# Altium schematic sheet Main uC.SchDoc("Main uC")
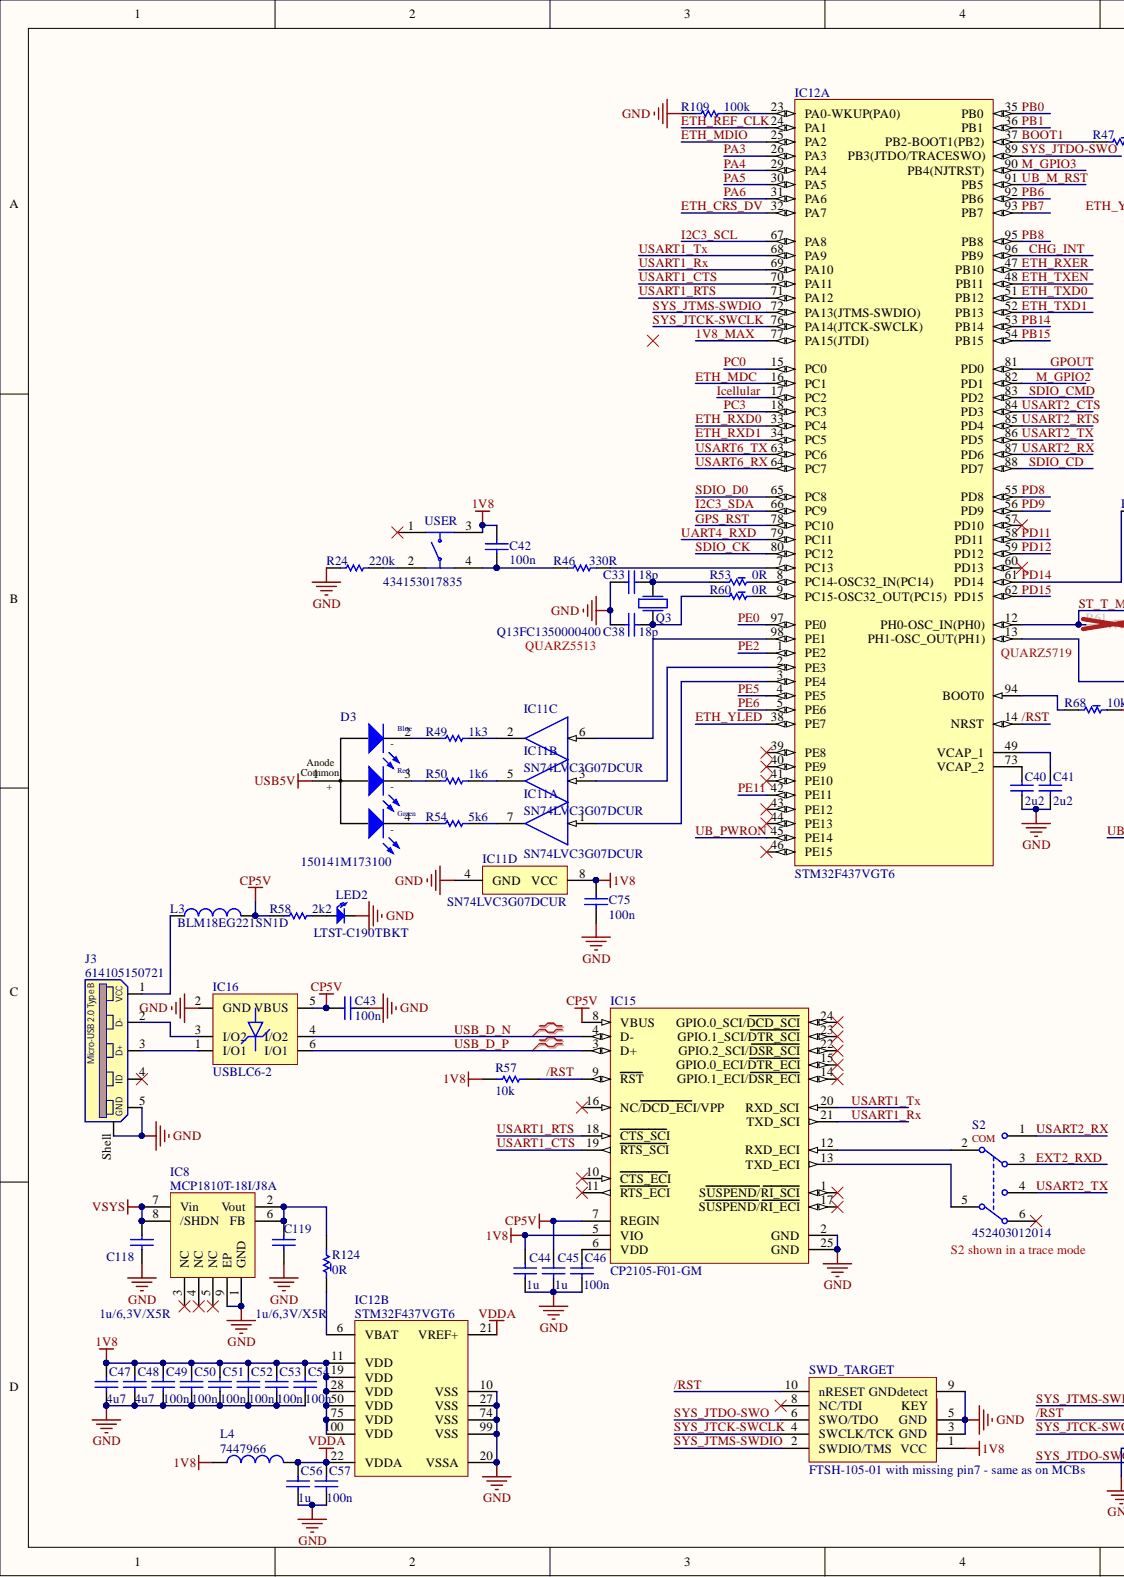
[diagram p0: Main uC.SchDoc, left]
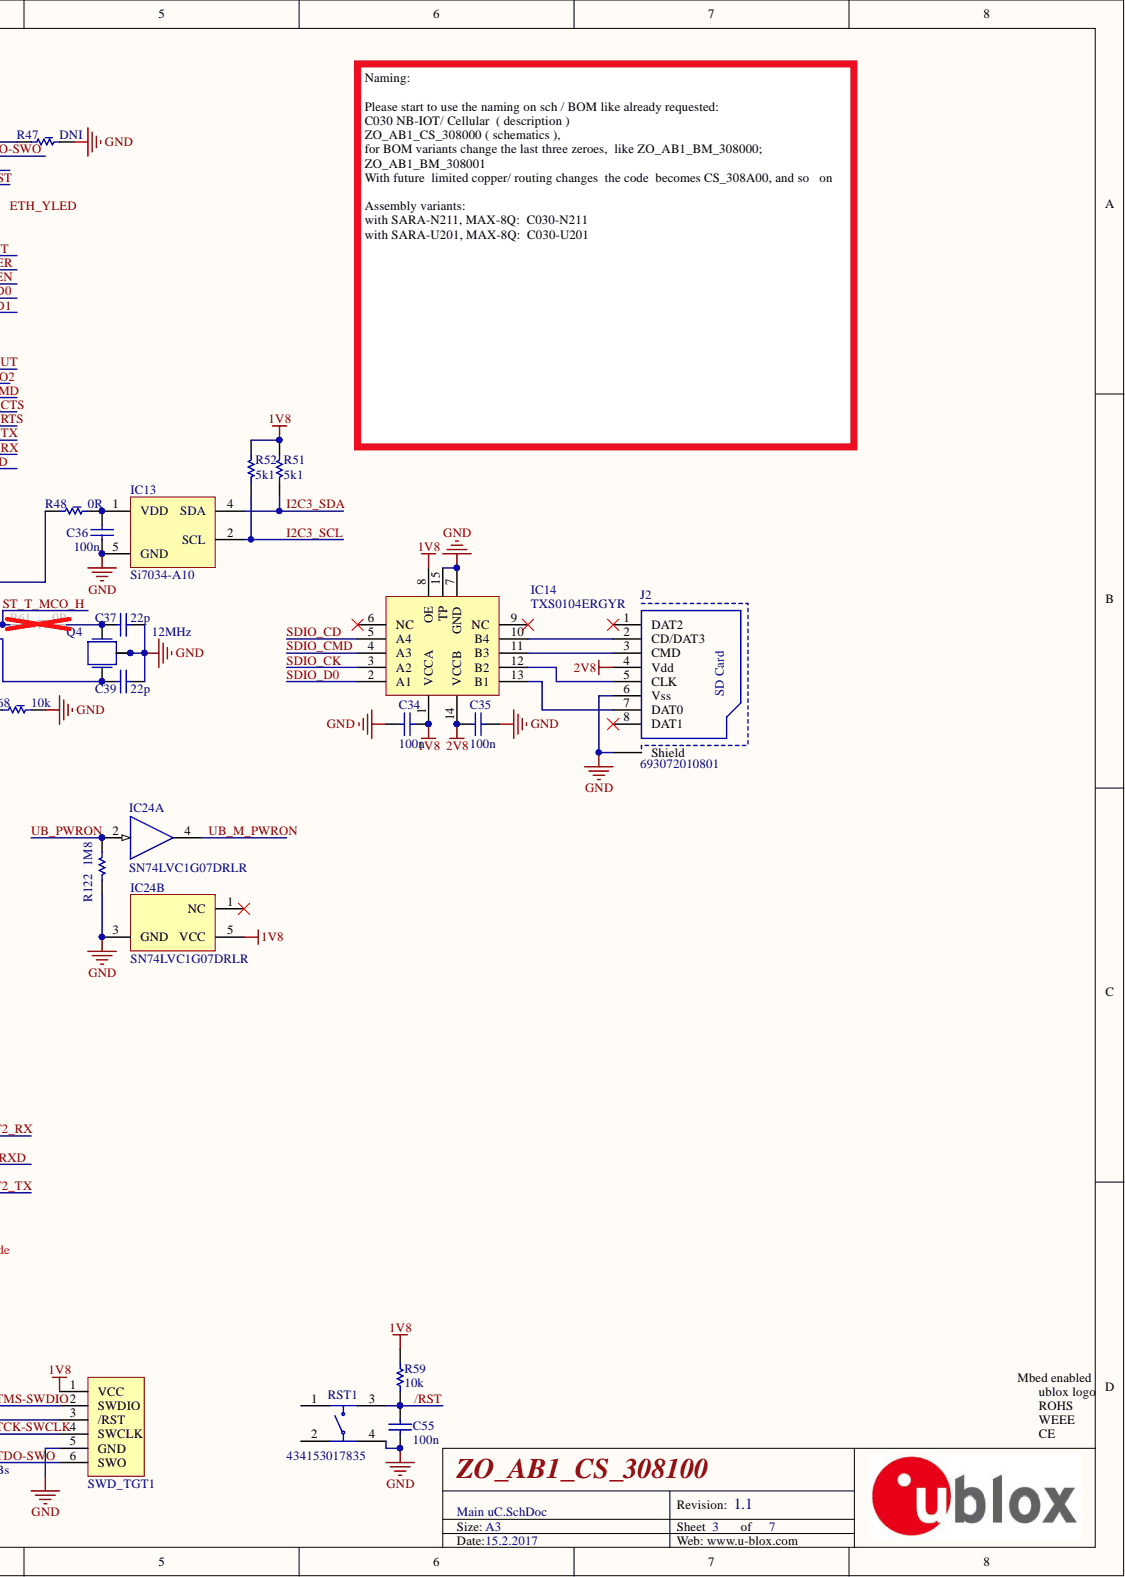
[diagram p1: Main uC.SchDoc, right]

## Parts
C33  18p  [C0402]
C34  100n  [C0402]
C35  100n  [C0402]
C36  100n  [C0402]
C37  22p  [C0402]
C38  18p  [C0402]
C39  22p  [C0402]
C40  2u2  [C0603]
C41  2u2  [C0603]
C42  100n  [C0402]
C43  100n  [C0402]
C44  1u  [C0603]
C45  1u  [C0603]
C46  100n  [C0402]
C47  4u7  [C0603]
C48  4u7  [C0603]
C49  100n  [C0402]
C50  100n  [C0402]
C51  100n  [C0402]
C52  100n  [C0402]
C53  100n  [C0402]
C54  100n  [C0402]
C55  100n  [C0402]
C56  1u  [C0603]
C57  100n  [C0402]
C75  100n  [C0402]
C118  1u/6,3V/X5R  [C0402]
C119  1u/6,3V/X5R  [C0402]
CEsign  CEsign  [CE - overlay]  (value not drawn)  (no BOM)
D3  150141M173100  [3528]
IC8  MCP1810T-18I/J8A  [DFN8(ST)]
IC11  SN74LVC3G07DCUR  [DCU0008A_L]  (parts A,B,C,D)
IC12  STM32F437VGT6  [STM-LQFP100_L]  (parts A,B)
IC13  Si7034-A10  [Si7034]
IC14  TXS0104ERGYR  [RGY-14N]
IC15  CP2105-F01-GM  [SLAB-QFN-24-2675x2675TP_N]
IC16  USBLC6-2  [SOT23-6L]
IC24  SN74LVC1G07DRLR  [DRL0005A_L]  (parts A,B)
J2  693072010801  [693072010801]
J3  614105150721  [614105150721]
L3  BLM18EG221SN1D  [L0603]
L4  7447966  [L0603]
LED2  LTST-C190TBKT  [LED0603 - BLUE]
Mbed_enabled  Mbed enabled  [Mbed enabled]  (no BOM)
Q3  Q13FC1350000400  [Q3215]
Q4  12MHz  [Q3225]
R24  220k  [R0402]
R46  330R  [R0402]
R47  DNI  [R0603pacman]
R48  0R  [R0603pacman]
R49  1k3  [R0402]
R50  1k6  [R0402]
R51  5k1  [R0402]
R52  5k1  [R0402]
R53  0R  [R0603pacman]
R54  5k6  [R0402]
R57  10k  [R0402]
R58  2k2  [R0402]
R59  10k  [R0402]
R60  0R  [R0603pacman]
R61  0R  [R0603pacman]
R68  10k  [R0603pacman]
R109  100k  [R0402]
R122  1M8  [R0402]
R124  0R  [R0603pacman]
ROHSsign  ROHSsign  [ROHSgrdi_overlay]  (value not drawn)  (no BOM)
RST1  434153017835  [434153017835]
S2  452403012014  [452403012014]
SWD_TARGET  FTSH-105-01 with missing pin7 - same as on MCBs  [SWD10 pin-7]
SWD_TGT1  TC2030-CTL-NL  [TC2030-MCP-NL]  (value not drawn)  (no BOM)
ublox_logo  ublox logo  [Ublox logo mini]  (no BOM)
USER  434153017835  [434153017835]
WEEEsign  WEEEsign  [WEEE - overlay]  (value not drawn)  (no BOM)

## Nets
1V8: C34.2 C42.2 C44.2 C47.2 C48.2 C49.2 C50.2 C51.2 C52.2 C53.2 C54.2 C75.2 IC11.8(VCC) IC12.11(VDD) IC12.19(VDD) IC12.28(VDD) IC12.50(VDD) IC12.75(VDD) IC12.100(VDD) IC14.1(VCCA) IC14.8(OE) IC15.5(VIO) IC24.5(VCC) L4.1 R51.2 R52.2 R57.1 R59.1 SWD_TARGET.1(VCC) SWD_TGT1.1(VCC) USER.3
2V8: C35.2 IC14.14(VCCB) J2.4(Vdd)
CP5V: C43.2 C45.2 IC15.7(REGIN) IC15.8(VBUS) IC16.5(VBUS) L3.2 R58.1
GND: C33.2 C34.1 C35.1 C36.1 C37.1 C38.2 C39.1 C40.1 C41.1 C43.1 C44.1 C45.1 C46.1 C47.1 C48.1 C49.1 C50.1 C51.1 C52.1 C53.1 C54.1 C55.1 C56.1 C57.1 C75.1 C118.1 C119.1 IC8.1(GND) IC8.9(EP) IC11.4(GND) IC12.10(VSS) IC12.20(VSSA) IC12.27(VSS) IC12.74(VSS) IC12.99(VSS) IC13.5(GND) IC14.7(GND) IC14.15(TP) IC15.2(GND) IC15.25(GND) IC16.2(GND) IC24.3(GND) J2.6(Vss) J2.Shield J3.5 J3.Shell LED2.K Q4.2 Q4.4 R24.2 R47.2 R68.2 R109.2 R122.1 RST1.4 SWD_TARGET.3(GND) SWD_TARGET.5(GND) SWD_TARGET.9(GNDdetect) SWD_TGT1.5(GND)
USB5V: D3.1
VDDA: C56.2 C57.2 IC12.21(VREF+) IC12.22(VDDA) L4.2
VSYS: C118.2 IC8.7(Vin) IC8.8(/SHDN)
1V8_MAX: IC12.77(PA15(JTDI))
/RST: C55.2 IC12.14(NRST) IC15.9(RST) R57.2 R59.2 RST1.3 SWD_TARGET.10(nRESET) SWD_TGT1.3(/RST)
BOOT1: IC12.37(PB2-BOOT1(PB2)) R47.1
CHG_INT: IC12.96(PB9)
ETH_CRS_DV: IC12.32(PA7)
ETH_MDC: IC12.16(PC1)
ETH_MDIO: IC12.25(PA2)
ETH_REF_CLK: IC12.24(PA1)
ETH_RXD0: IC12.33(PC4)
ETH_RXD1: IC12.34(PC5)
ETH_RXER: IC12.47(PB10)
ETH_TXD0: IC12.51(PB12)
ETH_TXD1: IC12.52(PB13)
ETH_TXEN: IC12.48(PB11)
ETH_YLED: IC12.38(PE7)
EXT2_RXD: S2.3
GPOUT: IC12.81(PD0)
GPS_RST: IC12.78(PC10)
I2C3_SCL: IC12.67(PA8) IC13.2(SCL) R52.1
I2C3_SDA: IC12.66(PC9) IC13.4(SDA) R51.1
ICELLULAR: IC12.17(PC2)
M_GPIO2: IC12.82(PD1)
M_GPIO3: IC12.90(PB4(NJTRST))
PA3: IC12.26(PA3)
PA4: IC12.29(PA4)
PA5: IC12.30(PA5)
PA6: IC12.31(PA6)
PB0: IC12.35(PB0)
PB1: IC12.36(PB1)
PB6: IC12.92(PB6)
PB7: IC12.93(PB7)
PB8: IC12.95(PB8)
PB14: IC12.53(PB14)
PB15: IC12.54(PB15)
PC0: IC12.15(PC0)
PC3: IC12.18(PC3)
PD8: IC12.55(PD8)
PD9: IC12.56(PD9)
PD11: IC12.58(PD11)
PD12: IC12.59(PD12)
PD14: IC12.61(PD14) R48.1
PD15: IC12.62(PD15)
PE0: IC12.97(PE0)
PE2: IC12.1(PE2)
PE5: IC12.4(PE5)
PE6: IC12.5(PE6)
PE11: IC12.42(PE11)
SDIO_CD: IC12.88(PD7) IC14.5(A4)
SDIO_CK: IC12.80(PC12) IC14.3(A2)
SDIO_CMD: IC12.83(PD2) IC14.4(A3)
SDIO_D0: IC12.65(PC8) IC14.2(A1)
ST_T_MCO_H: IC12.12(PH0-OSC_IN(PH0)) R61.1
SYS_JTCK-SWCLK: IC12.76(PA14(JTCK-SWCLK)) SWD_TARGET.4(SWCLK/TCK) SWD_TGT1.4(SWCLK)
SYS_JTDO-SWO: IC12.89(PB3(JTDO/TRACESWO)) SWD_TARGET.6(SWO/TDO) SWD_TGT1.6(SWO)
SYS_JTMS-SWDIO: IC12.72(PA13(JTMS-SWDIO)) SWD_TARGET.2(SWDIO/TMS) SWD_TGT1.2(SWDIO)
UART4_RXD: IC12.79(PC11)
UB_M_PWRON: IC24.4
UB_M_RST: IC12.91(PB5)
UB_PWRON: IC12.45(PE14) IC24.2 R122.2
USART1_CTS: IC12.70(PA11) IC15.19(RTS_SCI)
USART1_RTS: IC12.71(PA12) IC15.18(CTS_SCI)
USART1_RX: IC12.69(PA10) IC15.21(TXD_SCI)
USART1_TX: IC12.68(PA9) IC15.20(RXD_SCI)
USART2_CTS: IC12.84(PD3)
USART2_RTS: IC12.85(PD4)
USART2_RX: IC12.87(PD6) S2.1
USART2_TX: IC12.86(PD5) S2.4
USART6_RX: IC12.64(PC7)
USART6_TX: IC12.63(PC6)
USB_D_N: IC15.4(D-) IC16.4(I/O2)
USB_D_P: IC15.3(D+) IC16.6(I/O1)
NetC33_1: C33.1 Q3.2 R53.1
NetC36_2: C36.2 IC13.1(VDD) R48.2
NetC37_2: C37.2 Q4.3 R61.2
NetC38_1: C38.1 Q3.1 R60.1
NetC39_2: C39.2 IC12.13(PH1-OSC_OUT(PH1)) Q4.1
NetC40_2: C40.2 IC12.73(VCAP_2)
NetC41_2: C41.2 IC12.49(VCAP_1)
NetC42_1: C42.1 R46.1 USER.4
NetC46_2: C46.2 IC15.6(VDD)
NetC119_2: C119.2 IC8.2(Vout) IC8.6(FB) R124.1
NetD3_2: D3.2 R49.1
NetD3_3: D3.3 R50.1
NetD3_4: D3.4 R54.1
NetIC11_1: IC11.1 IC12.3(PE4)
NetIC11_2: IC11.2 R49.2
NetIC11_3: IC11.3 IC12.2(PE3)
NetIC11_5: IC11.5 R50.2
NetIC11_6: IC11.6 IC12.98(PE1)
NetIC11_7: IC11.7 R54.2
NetIC12_6: IC12.6(VBAT) R124.2
NetIC12_7: IC12.7(PC13) R46.2
NetIC12_8: IC12.8(PC14-OSC32_IN(PC14)) R53.2
NetIC12_9: IC12.9(PC15-OSC32_OUT(PC15)) R60.2
NetIC12_23: IC12.23(PA0-WKUP(PA0)) R109.1
NetIC12_94: IC12.94(BOOT0) R68.1
NetIC14_10: IC14.10(B4) J2.2(CD/DAT3)
NetIC14_11: IC14.11(B3) J2.3(CMD)
NetIC14_12: IC14.12(B2) J2.5(CLK)
NetIC14_13: IC14.13(B1) J2.7(DAT0)
NetIC15_12: IC15.12(RXD_ECI) S2.2
NetIC15_13: IC15.13(TXD_ECI) S2.5
NetIC16_1: IC16.1(I/O1) J3.3(D+)
NetIC16_3: IC16.3(I/O2) J3.2(D-)
NetJ3_1: J3.1 L3.1
NetLED2_A: LED2.A R58.2
NetR24_1: R24.1 USER.2

Not connected: IC8 3(NC) 4(NC) 5(NC); IC12 39(PE8) 40(PE9) 41(PE10) 43(PE12) 44(PE13) 46(PE15) 57(PD10) 60(PD13); IC14 6(NC) 9(NC); IC15 1(SUSPEND/RI_SCI) 10(CTS_ECI) 11(RTS_ECI) 14(GPIO.1_ECI/DSR_ECI) 15(GPIO.0_ECI/DTR_ECI) 16(NC/DCD_ECI/VPP) 17(SUSPEND/RI_ECI) 22(GPIO.2_SCI/DSR_SCI) 23(GPIO.1_SCI/DTR_SCI) 24(GPIO.0_SCI/DCD_SCI); IC24 1(NC); J2 1(DAT2) 8(DAT1); J3 4(ID); RST1 1 2; S2 6; SWD_TARGET 8(NC/TDI); USER 1

## Library data of the parts
C33-C57 C75 C118 C119 = Capacitor SMD: SMD chip capacitor; Value: Xn; Component Kind: Standard; LatestRevisionDate: 26-Dec-2008; Published: 26-Dec-2008; Signal Integrity: Cap; Simulation: CAP; Library Name: BorisPassive.SchLib; Publisher: www.poscope.com
D3 = WL-SFTW 3528, 150141M173100: WL-SFTW SMD TOP LED full-color waterclear, 3528, Red & Green & Blue; Manufacturer: Wurth Elektronik; Component Kind: Standard; ComponentLink1Description: Manufacturer; ComponentLink1URL: http://www.we-online.de; ComponentLink2Description: Datasheet; ComponentLink2URL: http://katalog.we-online.de/led/datasheet/150141M173100.pdf; Created Date: March 2016; Library Name: ALTIUM-WL-SFTW-rev16a.IntLib; PartNo: 150141M173100; Created by: Baojun Chen
IC8 = MCP1810T-18I/J8A: Ultra-Low Quiescent Current LDO Regulator; Component Kind: Standard; Library Name: BorisIC1.SchLib
IC11 = SN74LVC3G07DCUR: Triple Buffer/Driver With Open-Drain Output, DCU0008A, LARGE T&R; Manufacturer: Texas Instruments; 3-State Output: No; Bits(#): 3; F @ Nom Voltage(Max)(Mhz): 150; ICC @ Nom Voltage(Max)(mA): 0.01; Input Type: CMOS/TTL; Logic: True; Output Drive (IOL/IOH)(Max)(mA): 32/-32; Output Type: CMOS; Rating: Catalog; Sub-Family: Non-Inverting Buffer/Driver; Technology Family: LVC; tpd @ Nom Voltage(Max)(ns): 8.3,4.8,4.2,3.4; VCC(Max)(V): 5.5; VCC(Min)(V): 1.65; Voltage(Nom)(V): 1.8,2.5,3.3,5; Component Kind: Standard; ComponentLink1Description: Manufacturer URL; ComponentLink1URL: http://www.ti.com/; ComponentLink2Description: Datasheet; ComponentLink2URL: http://www.ti.com/lit/ds/symlink/sn74lvc3g07.pdf; DatasheetVersion: SCES365K; Generic Part Number: SN74LVC3G07; Library Name: TI_Logic_Little_Logic.IntLib; Operating Temperature Range(C): -40 to 125,-40 to 85; PackageReference: DCU0008A; PartNumber: SN74LVC3G07DCUR; Schmitt Trigger: No; Supplier 1: Mouser; Supplier 2: Digi-Key; Supplier 3: Digi-Key; Supplier 4: Digi-Key; Supplier Part Number 1: 595-SN74LVC3G07DCUR; Supplier Part Number 2: 296-13283-2-ND; Supplier Part Number 3: 296-13283-1-ND; Supplier Part Number 4: 296-13283-6-ND
IC12 = STM32F437VGT6: ARM Cortex-M4 32-bit MCU+FPU, 210 DMIPS, 1024 kB Flash, 256 kB Internal RAM, 82 I/Os, 100-pin LQFP, -40 to 85 degC, Tray; Manufacturer: STMicroelectronics; D/A Converter: 2x12-bit; FLASH Size (Prog) (kB): 1024; I/Os (High Current): 82; Internal RAM Size (kB): 256; Operating Frequency(F) (Processor speed) (MHz): 168; Supply Voltage(Vcc) max (V): 3.6; Supply Voltage(Vcc) min (V): 1.8; Component Kind: Standard; ComponentLink1Description: Manufacturer URL; ComponentLink1URL: http://www.st.com/web/en/home.html; ComponentLink2Description: Datasheet; ComponentLink2URL: http://www.st.com/st-web-ui/static/active/en/resource/technical/document/datasheet/DM00077036.pdf; ComponentLink3Description: Package Specification; ComponentLink3URL: http://www.st.com/st-web-ui/static/active/en/resource/technical/document/data_brief/DM00071938.pdf; Core: ARM Cortex-M4; DatasheetVersion: Rev. 1; Library Name: STMicroelectronics STM32 F4.IntLib; Mounting Technology: Surface Mount; PackageDescription: 100-Pin Low Profile Quad Flat Package, 14 x 14 mm Body, 0.5 mm Pitch; PackageReference: LQFP100; PackageVersion: Rev. 1, 06/2013; Packing: Tray; PartNumber: STM32F437VGT6; Supplier 1: Digi-Key; Supplier 2: Farnell; Supplier 3: Newark; Supplier 4: Newark; Supplier 5: Mouser; Supplier Part Number 1: STM32F437VGT6-ND; Supplier Part Number 2: 2333376; Supplier Part Number 3: 99W9933; Supplier Part Number 4: 66W7317; Supplier Part Number 5: 511-STM32F437VGT6
IC13 = Si7034-A10: Digital I2C humidity and temperature sensor; Component Kind: Standard; Library Name: BorisSensors.SchLib
IC14 = TXS0104ERGYR: 4-Bit Bi-directional, Level-Shifting, Voltage Translator  for Open-Drain and Push-Pull Applications; Component Kind: Standard; Library Name: BorisIC1.SchLib
IC15 = CP2105-F01-GM: Single-Chip USB to Dual UART Bridge, 5 Dig I/O Pins, Internal Oscillator, 296 Bytes EEPROM, -40 to 85 degC, 24-pin QFN; Manufacturer: Silicon Labs; Internal Oscillator: TRUE; Sub-Family: USB Bridges; Code_IPC: J-STD-020; Code_JEDEC: MO-220; Component Kind: Standard; ComponentLink1Description: Manufacturer URL; ComponentLink1URL: http://www.silabs.com/; ComponentLink2Description: Datasheet; ComponentLink2URL: http://www.silabs.com/Support%20Documents/TechnicalDocs/CP2105.pdf; ComponentLink3Description: Package Specification; ComponentLink3URL: http://www.silabs.com/Support%20Documents/TechnicalDocs/C8051F93x-92x.pdf; DatasheetVersion: Rev. 1.0; EEPROM: 296 Bytes; Library Name: Silicon Labs Interface.IntLib; Mounting Technology: Surface Mount; PackageDescription: 24-Pin Quad-Flat No-Leads Package, Body 4 x 4 mm, Pitch 0.5 mm; PackageReference: QFN-24; PartNumber: CP2105-F01-GM; Supplier 1: Digi-Key; Supplier 2: Mouser; Supplier Part Number 1: 336-2009-5-ND; Supplier Part Number 2: 634-CP2105-F01-GM
IC16 = USBLC6-2: Very low capacitance ESD protection; Component Kind: Standard; Library Name: BorisIC1.SchLib
IC24 = SN74LVC1G07DRLR: Single Buffer/Driver With Open-Drain Output, DRL0005A, LARGE T&R; Manufacturer: Texas Instruments; 3-State Output: No; Bits(#): 1; F @ Nom Voltage(Max)(Mhz): 150; ICC @ Nom Voltage(Max)(mA): 0.01; Input Type: CMOS/TTL; Logic: True; Output Drive (IOL/IOH)(Max)(mA): 32/-32; Output Type: CMOS; Rating: Catalog; Sub-Family: Non-Inverting Buffer/Driver; Technology Family: LVC; tpd @ Nom Voltage(Max)(ns): 8.6,6,4.7,4; VCC(Max)(V): 5.5; VCC(Min)(V): 1.65; Voltage(Nom)(V): 1.8,2.5,3.3,5; Component Kind: Standard; ComponentLink1Description: Manufacturer URL; ComponentLink1URL: http://www.ti.com/; ComponentLink2Description: Datasheet; ComponentLink2URL: http://www.ti.com/lit/ds/symlink/sn74lvc1g07.pdf; DatasheetVersion: SCES296AC; Generic Part Number: SN74LVC1G07; Library Name: TI_Logic_Little_Logic.IntLib; Operating Temperature Range(C): -40 to 125,-40 to 85; PackageReference: DRL0005A; PartNumber: SN74LVC1G07DRLR; Schmitt Trigger: No; Supplier 1: Mouser; Supplier 2: Digi-Key; Supplier 3: Digi-Key; Supplier 4: Digi-Key; Supplier Part Number 1: 595-SN74LVC1G07DRLR; Supplier Part Number 2: 296-18010-2-ND; Supplier Part Number 3: 296-18010-1-ND; Supplier Part Number 4: 296-18010-6-ND
J2 = 693072010801: WR-CRD Micro SD Card Connector - Hinge - 8 pins; Component Kind: Standard; ComponentLink1Description: Manufacturer; ComponentLink1URL: http://www.we-online.de; ComponentLink2Description: Datasheet; ComponentLink2URL: http://katalog.we-online.de/em/datasheet/693072010801.pdf; Library Name: ALTIUM-WR-CRD-rev16c.IntLib; PartNumber: 693072010801; Published: June 2016; Publisher: Wurth Elektronik
J3 = 614105150721: WR-COM Micro USB 2.0 Type B Vertical 5 Contacts; Component Kind: Standard; ComponentLink1Description: Manufacturer; ComponentLink1URL: http://www.we-online.de; ComponentLink2Description: Datasheet; ComponentLink2URL: http://katalog.we-online.de/em/datasheet/614105150721.pdf; Library Name: ALTIUM-WR-COM-rev16d.IntLib; PartNumber: 614105150721; Published: June 2016; Publisher: Wurth Elektronik
L3 L4 = Inductor SMD: Value: XuH; Component Kind: Standard; LatestRevisionDate: 21 March 2009; Published: 21 March 2009; Library Name: BorisPassive.SchLib; Publisher: www.poscope.com
LED2 = LEDmini: Component Kind: Standard; Description: LEDmini; Library Name: BorisSemi.SchLib
Q3 = Crystal: 32.768 kHz crystals; Component Kind: Standard; Library Name: BorisPassive.SchLib
Q4 = Crystal Quarz: Quarz crystals; Component Kind: Standard; Library Name: BorisPassive.SchLib
R24 R46 R49-R52 R54 R57-R59 R109 R122 = Resistor SMD: SMD chip resistor; Value: Xk; Component Kind: Standard; LatestRevisionDate: 26-Dec-2008; Published: 26-Dec-2008; Signal Integrity: Res Semi; Simulation: RES; Library Name: BorisPassive.SchLib; Publisher: www.poscope.com
R47 R48 R53 R60 R61 R68 R124 = Resistor Pacman SMD: SMD chip resistor with Payman for easy soldering; Value: Xk; Component Kind: Standard; LatestRevisionDate: 26-Dec-2008; Published: 26-Dec-2008; Signal Integrity: Res Semi; Simulation: RES; Library Name: BorisPassive.SchLib; Publisher: www.poscope.com
RST1 USER = WS-TASV, 434153017835: WS-TASV 3.5x2.9 mm SMD J-Bend; Manufacturer: Wurth Elektronik; Component Kind: Standard; ComponentLink1Description: Manufacturer; ComponentLink1URL: http://www.we-online.de; ComponentLink2Description: Datasheet; ComponentLink2URL: http://katalog.we-online.de/em/datasheet/434153017835.pdf; Created Date: Dec.2015; Library Name: ALTIUM-WS-TASV-rev16a.IntLib; partNo: 434153017835; Created by: Baojun Chen
S2 = WS-SLSV, 452403012014: WS-SLSV Slide Switch, SMD version, same side connection; Manufacturer: Wurth Elektronik; Component Kind: Standard; ComponentLink1Description: Manufacturer; ComponentLink1URL: http://www.we-online.de; ComponentLink2Description: Datasheet; ComponentLink2URL: http://katalog.we-online.de/em/datasheet/452403012014.pdf; Created Date: Dec.2015; Library Name: ALTIUM-WS-SLSV-rev15a.IntLib; partNo: 452403012014; Created by: Baojun Chen
SWD_TARGET = SWD 10pin-7: SW Debug connector, 10 pole, vertical, Male, missing pin 7; Samtec: FTSH-105-01-L-DV-K; Component Kind: Standard; Library Name: BorisConProg.SchLib; Publisher: Boris
SWD_TGT1 = CortexSWD: Tag Connect programming adapter footprint; Library Name: BorisConProg.SchLib
CEsign = CEsign: Description: CEsign; Library Name: BorisLogo.SchLib
ROHSsign = ROHSsign: Description: ROHSsign; Library Name: BorisLogo.SchLib
WEEEsign = WEEEsign: Description: WEEEsign; Library Name: BorisLogo.SchLib
Mbed_enabled = Mbed enabled: Library Name: BorisLogo.SchLib
ublox_logo = ublox logo: Library Name: BorisLogo.SchLib
